# Altium schematic sheet MonitorDB.SchDoc(MonitorDB)
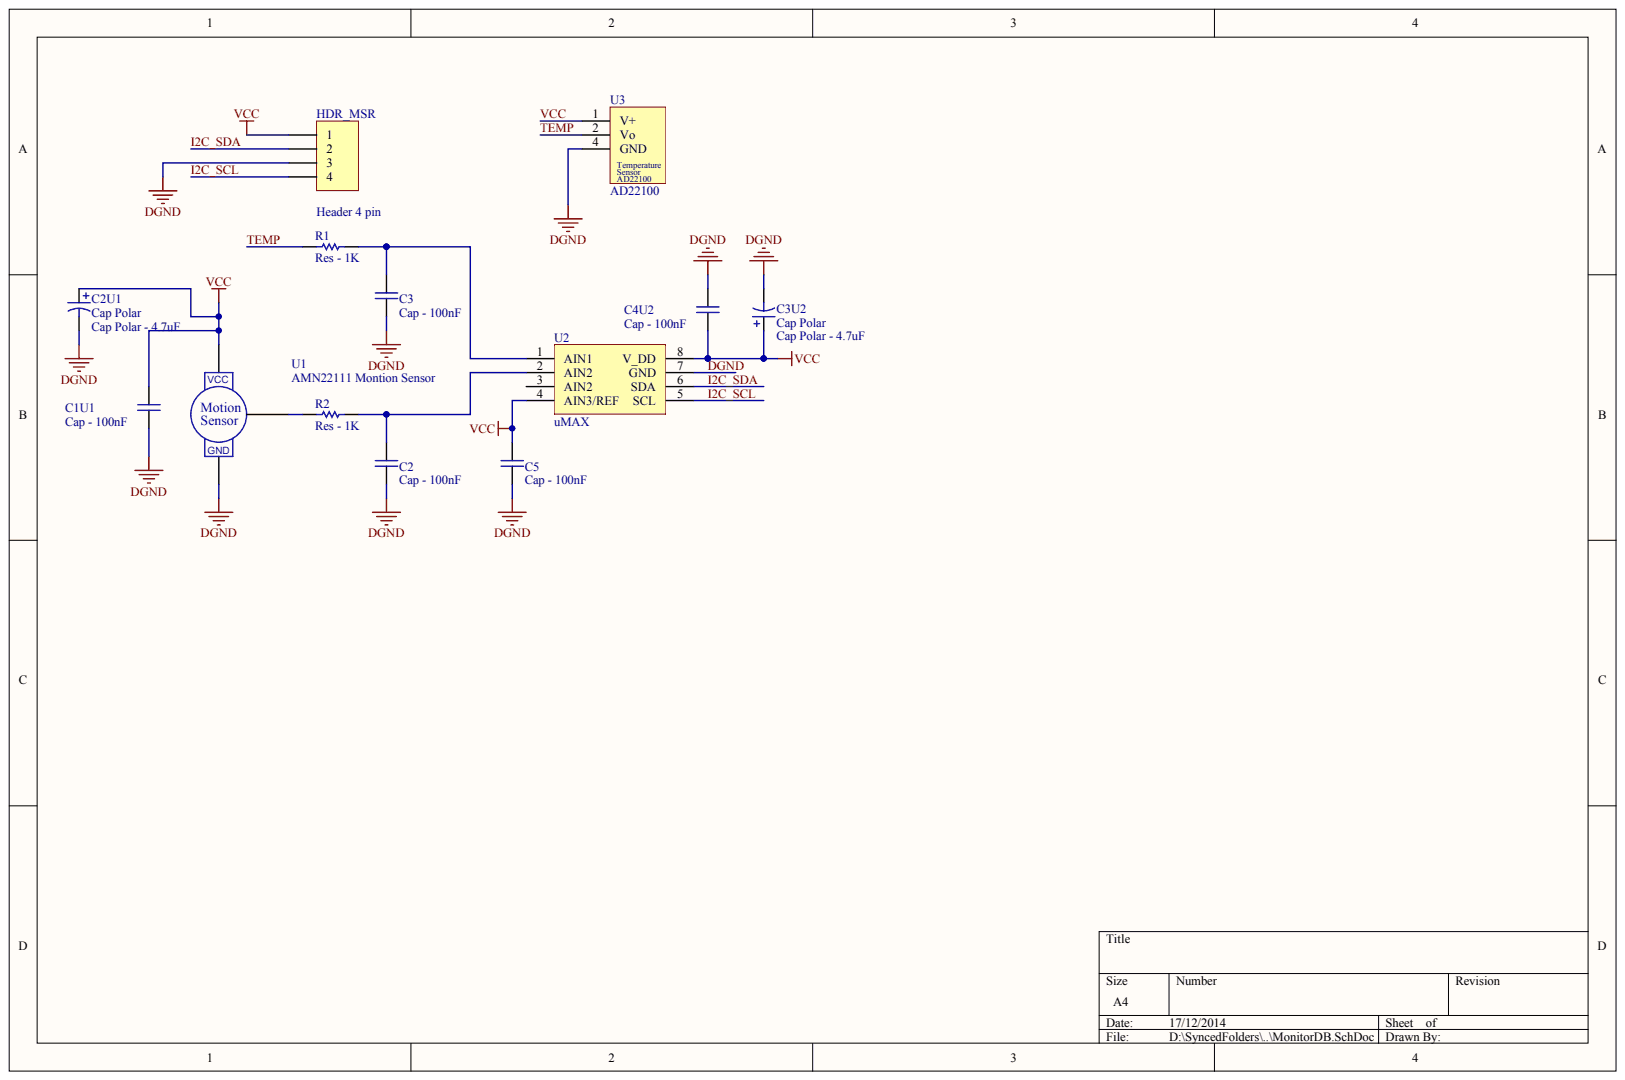
[diagram p0: MonitorDB.SchDoc]

## Parts
C1U1  Cap  value Cap - 100nF
C2  Cap  value Cap - 100nF
C2U1  Cap Polar  value Cap Polar - 4.7uF
C3  Cap  value Cap - 100nF
C3U2  Cap Polar  value Cap Polar - 4.7uF
C4U2  Cap  value Cap - 100nF
C5  Cap  value Cap - 100nF
HDR_MSR  value Header 4 pin  (hidden comment: Header - 1X4)
R1  value Res - 1K  (hidden comment: Resistor)
R2  value Res - 1K  (hidden comment: Resistor)
U1  AMN22111 Montion Sensor  value AMN22111
U2  uMAX
U3  AD22100

## Nets
DGND: C1U1.2 C2.2 C2U1.2 C3.2 C3U2.2 C4U2.2 C5.2 HDR_MSR.3 U1.0 U2.7(GND) U3.4
I2C_SCL: HDR_MSR.4 U2.5(SCL)
I2C_SDA: HDR_MSR.2 U2.6(SDA)
TEMP: R1.1 U3.2
VCC: C1U1.1 C2U1.1 C3U2.1 C4U2.1 C5.1 HDR_MSR.1 U1.2 U2.4(AIN3/REF) U2.8(V_DD) U3.1
NetC2_1: C2.1 R2.2 U2.2(AIN2)
NetC3_1: C3.1 R1.2 U2.1(AIN1)
NetR2_1: R2.1 U1.1

Not connected: U2 3(AIN2)

## Library data of the parts
C2U1 = (no symbol name): Value: Cap Polar - 4.7uF; Digi-Key Part Number: 493-5138-1-ND
HDR_MSR = (no symbol name): Value: Header 4 pin; Revision: 17-Jul-2002: Re-released for DXP Platform.; Digi-Key Part Number: WM4202-ND; Publisher: Altium Limited
U2 U3 = (no symbol name): Value: MAX5805; Digi-Key Part Number: MAX5805AAUB+-ND
C1U1 C2 C3 C3U2 C4U2 C5 R1 R2 U1 = (no symbol name)
  C1U1 C2 C3 C4U2 C5: Digi-Key Part Number: Digi-Key Part Number
  C3U2: Digi-Key Part Number: 493-5138-1-ND
  R1 R2: Published: 8-Jun-2000; Revision: July-2002: Re-released for DXP Platform.; Digi-Key Part Number: CF14JT1K00CT-ND; Publisher: Altium Limited
